# Altium schematic sheet 06_STARNOTE-CONNECTION.SchDoc
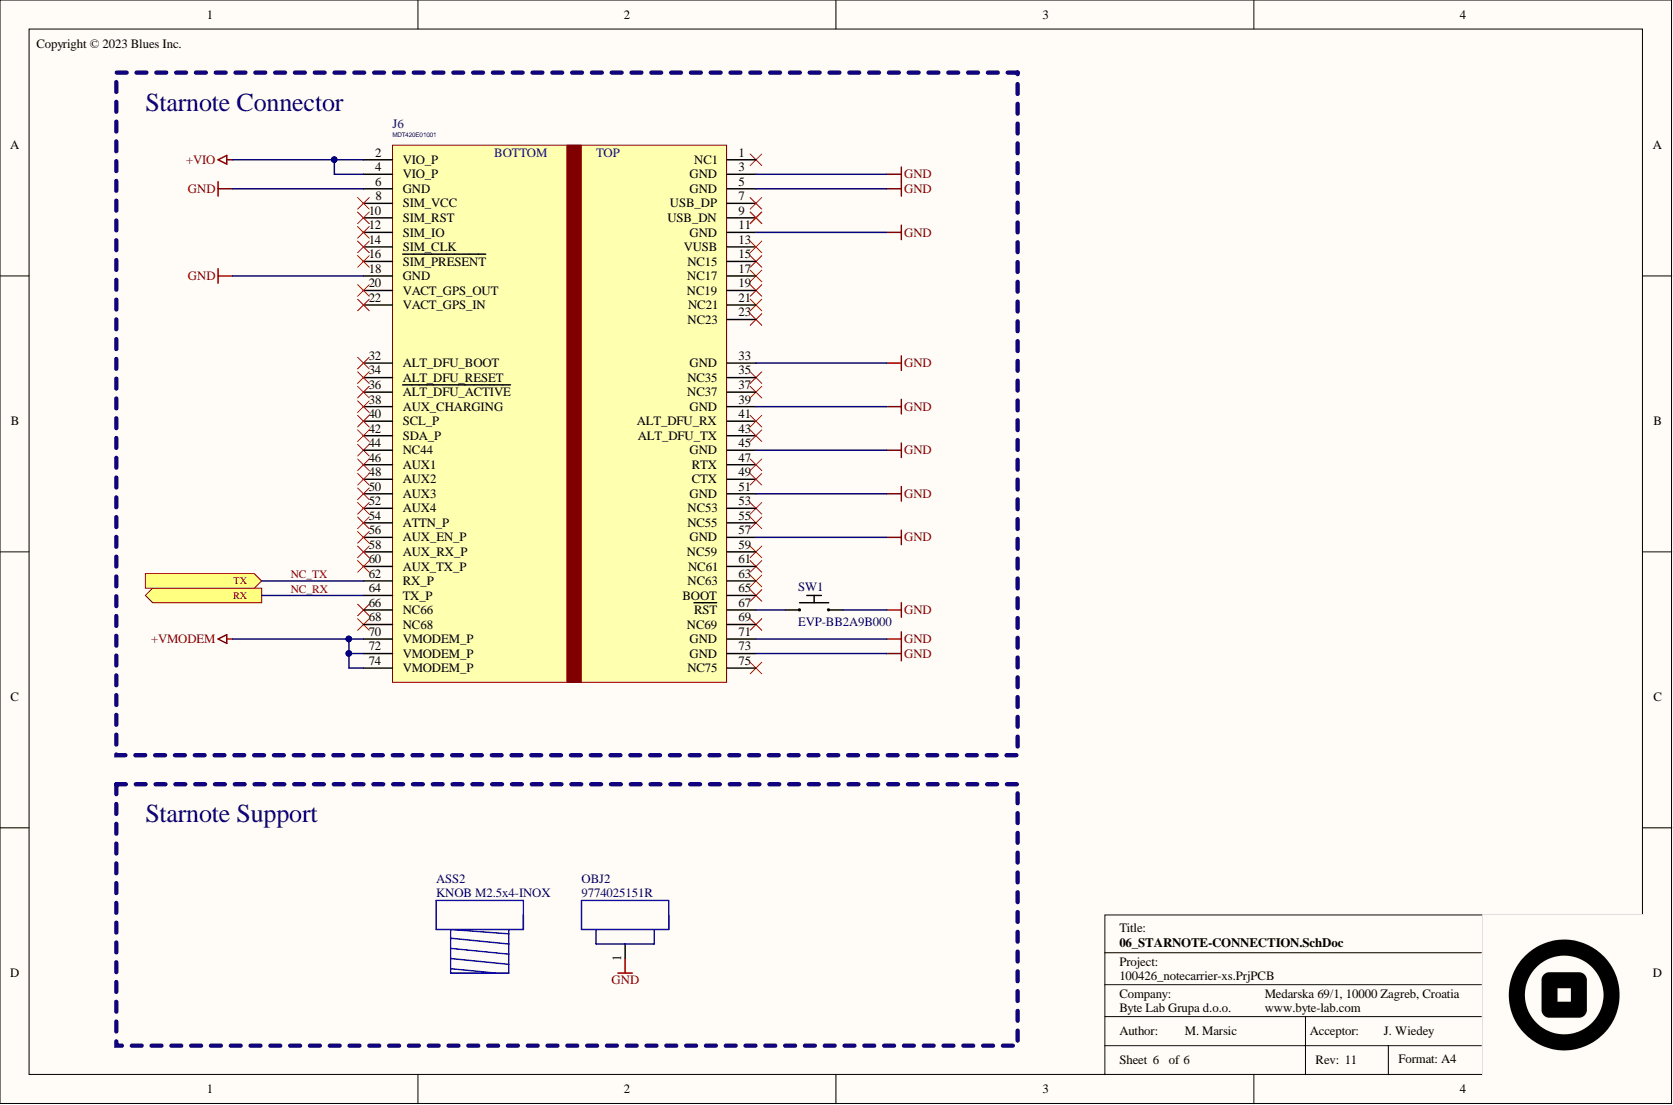
[diagram p0: 06_STARNOTE-CONNECTION.SchDoc]

## Parts
ASS2  KNOB M2.5x4-INOX  (mechanical)
J6  MDT420E01001  [J-75-0050-MOS-M2]
OBJ2  9774025151R  [DIST-WASMSIM0250]
SW1  EVP-BB2A9B000  [SW_EVP-BB2A9B000]

## Ports
RX, TX

## Nets
+VIO: J6.2(VIO_P) J6.4(VIO_P)
+VMODEM: J6.70(VMODEM_P) J6.72(VMODEM_P) J6.74(VMODEM_P)
GND: J6.3(GND) J6.5(GND) J6.6(GND) J6.11(GND) J6.18(GND) J6.33(GND) J6.39(GND) J6.45(GND) J6.51(GND) J6.57(GND) J6.71(GND) J6.73(GND) OBJ2.1 SW1.2
NC_RX: J6.64(TX_P) ; port RX
NC_TX: J6.62(RX_P) ; port TX
NetJ6_67: J6.67(RST) SW1.1

Not connected: J6 1(NC1) 7(USB_DP) 8(SIM_VCC) 9(USB_DN) 10(SIM_RST) 12(SIM_IO) 13(VUSB) 14(SIM_CLK) 15(NC15) 16(SIM_PRESENT) 17(NC17) 19(NC19) 20(VACT_GPS_OUT) 21(NC21) 22(VACT_GPS_IN) 23(NC23) 32(ALT_DFU_BOOT) 34(ALT_DFU_RESET) 35(NC35) 36(ALT_DFU_ACTIVE) 37(NC37) 38(AUX_CHARGING) 40(SCL_P) 41(ALT_DFU_RX) 42(SDA_P) 43(ALT_DFU_TX) 44(NC44) 46(AUX1) 47(RTX) 48(AUX2) 49(CTX) 50(AUX3) 52(AUX4) 53(NC53) 54(ATTN_P) 55(NC55) 56(AUX_EN_P) 58(AUX_RX_P) 59(NC59) 60(AUX_TX_P) 61(NC61) 63(NC63) 65(BOOT) 66(NC66) 68(NC68) 69(NC69) 75(NC75)

## Library data of the parts
ASS2 = KNOB - M2.5x4-INOX: VITE TCEI 2.5X4 ESAGONO INCASSATO A2 INOX; BL Part Number: 6000-168; Manufacturer: KRAFTBERG; Manufacturer Part Number: M2.5X4/D7985-A2; Drawn_By: Blues Wireless; Library Name: n21-p2.SchLib; Supplier 1: TME; Supplier Part Number 1: M2.5X4/D7985-A2
J6 = AMPHENOL-75 - M-2 KEY E_13: CONN. M.2 75P M/90° P=0.5 MDT420E01001 AMPHENOL SMT; BL Part Number: 2007-460; Manufacturer: Amphenol ICC (FCI); Manufacturer Part Number: MDT420E03001; Drawn_By: Blues Wireless; Component Kind: Standard; Library Name: n21-p2.SchLib; Supplier 1: Digi-Key; Supplier Part Number 1: MDT420E03001CT-ND
OBJ2 = DISTANZIALE - M2.5x0250: DISTANZ. CIL. METALLO 5.1X2.5 9774025151R W.E SMT; BL Part Number: 6020-113; Manufacturer: Würth Elektronik; Drawn_By: Blues Wieless; Component Kind: Standard; Library Name: n21-p2.SchLib; Manufacturer Part Number: 9774025151R; Supplier 1: Digi-Key; Supplier Part Number 1: 732-7078-1-ND
SW1 = Switch tact EVP-BB2A9B000: SWITCH TACTILE SPST-NO 0.05A 15V; BL Part Number: 2007-124; Manufacturer: Panasonic Electronic Components; Drawn_By: Marsic; Component Kind: Standard; DateModified: 09-02-2023; Library Name: BL_Mechanical.SchLib; Manufacturer Part Number: EVP-BB2A9B000
